# Altium schematic sheet Connector.SchDoc(Connector)
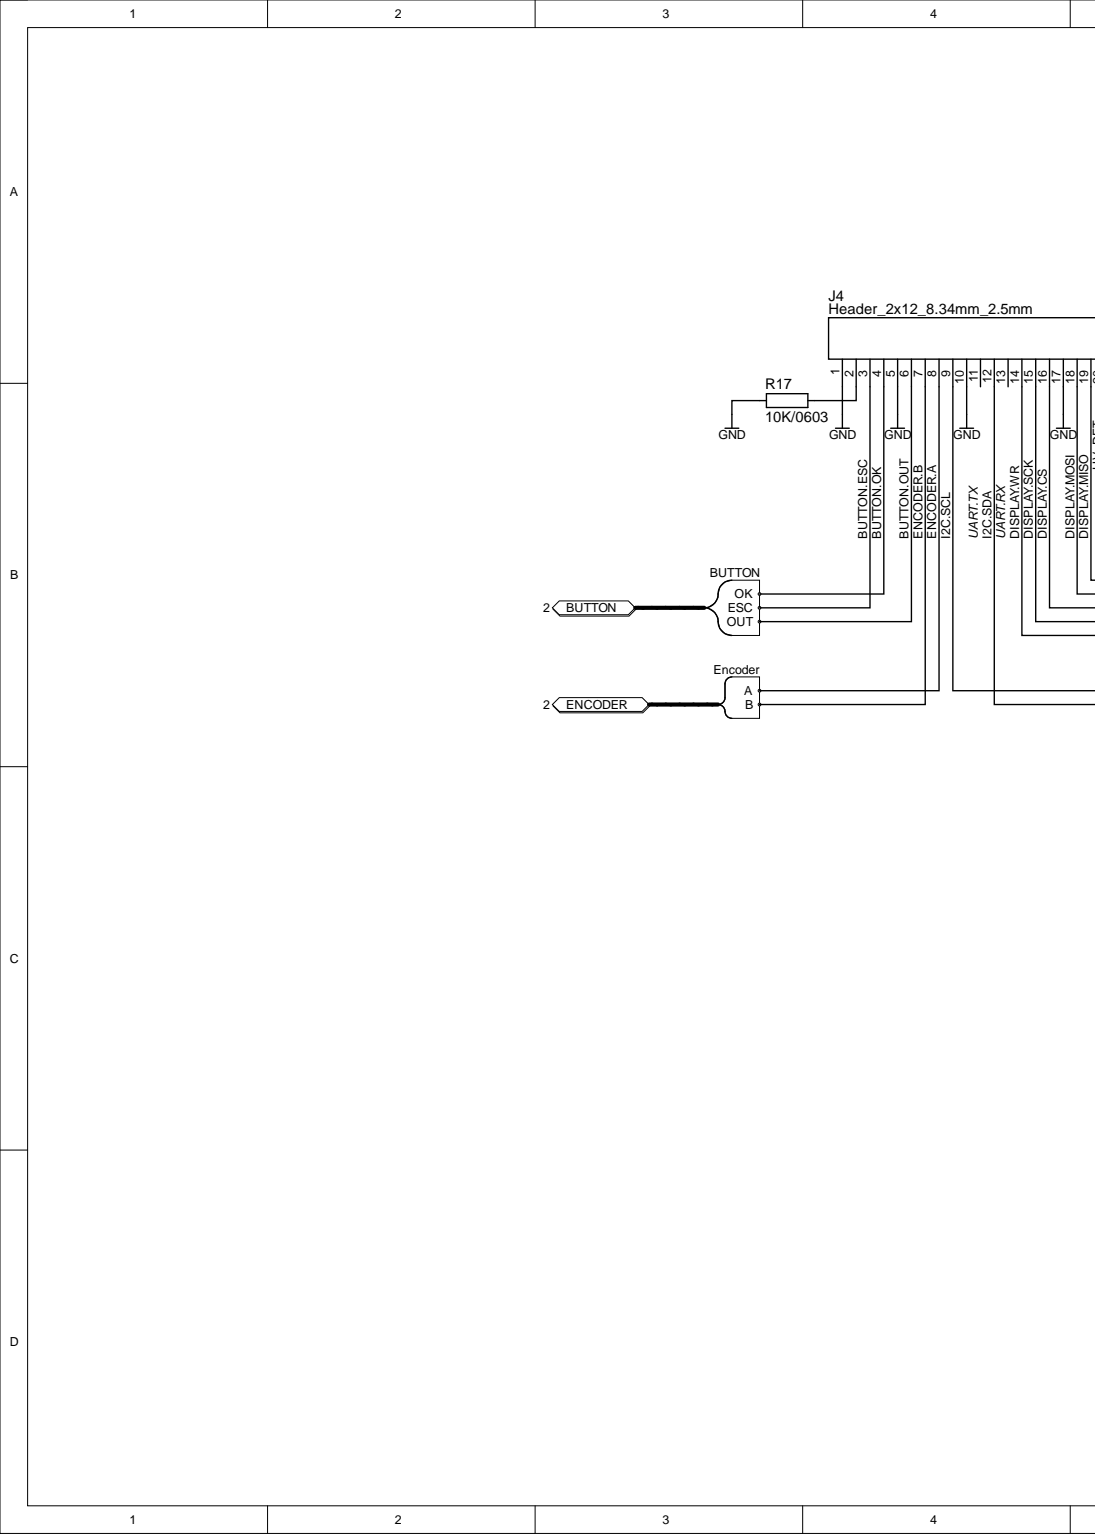
[diagram p0: Connector.SchDoc, left]
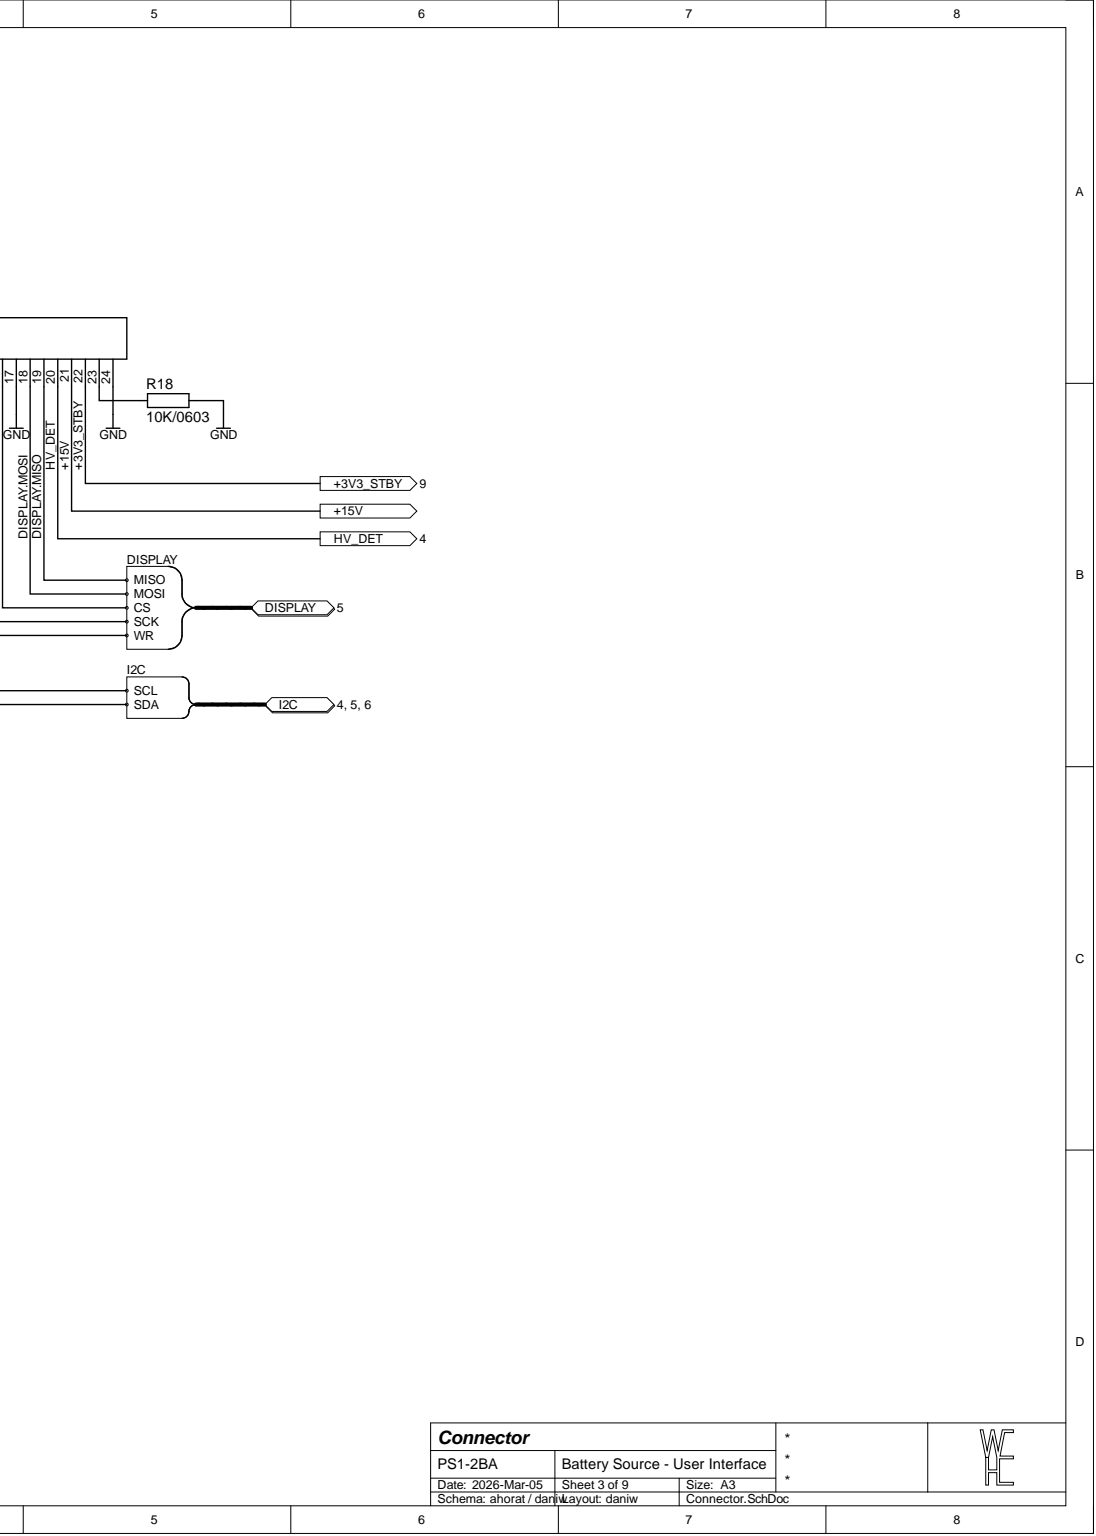
[diagram p1: Connector.SchDoc, right]

## Parts
J4  Header_2x12_8.34mm_2.5mm  [HEAD_254_2X12_834_250]
R17  10K/0603  [R0603-0.40-WW]
R18  10K/0603  [R0603-0.40-WW]

## Ports
+3V3_STBY, +15V, BUTTON, BUTTON.ESC, BUTTON.OK, BUTTON.OUT, DISPLAY, DISPLAY.CS, DISPLAY.MISO, DISPLAY.MOSI, DISPLAY.SCK, DISPLAY.WR, ENCODER, ENCODER.A, ENCODER.B, HV_DET, I2C, I2C.SCL, I2C.SDA

## Nets
GND: J4.1 J4.5 J4.10 J4.17 J4.24 R17.1 R18.2
+3V3_STBY: J4.22 ; port +3V3_STBY
+15V: J4.21 ; port +15V
BUTTON.ESC: J4.3 ; port BUTTON
BUTTON.OK: J4.4 ; port BUTTON
BUTTON.OUT: J4.6 ; port BUTTON
DISPLAY.CS: J4.16 ; port DISPLAY
DISPLAY.MISO: J4.19 ; port DISPLAY
DISPLAY.MOSI: J4.18 ; port DISPLAY
DISPLAY.SCK: J4.15 ; port DISPLAY
DISPLAY.WR: J4.14 ; port DISPLAY
ENCODER.A: J4.8 ; port ENCODER
ENCODER.B: J4.7 ; port ENCODER
HV_DET: J4.20 ; port HV_DET
I2C.SCL: J4.9 ; port I2C
I2C.SDA: J4.12 ; port I2C
NetJ4_2: J4.2 R17.2
NetJ4_11: J4.11
NetJ4_13: J4.13
NetJ4_23: J4.23 R18.1

## Library data of the parts
J4 = Header_2x12_8.34mm_2.5mm: Header 100 mil 2x12, Height 8.34mm, flange height 2.5mm; Manufacturer: GENERIC; Manufacturer 1: Sullins Connector Solutions; Manufacturer Part Number 1: PRPC012DAAN-RC; Database Table Name: CON$; System: Connector; Component Kind: Standard; Part Number: Header_2x12_8.34mm_2.5mm; Supplier 1: Digi-Key; Supplier Part Number 1: S2011EC-12-ND; Library Name: daniw_altium_lib.DbLib
R17 R18 = 10K/0603: RES SM 0603 10K; Manufacturer: GENERIC; Manufacturer 1: YAGEO; Manufacturer Part Number 1: RC0603FR-1310KL; Absolute Value: 10000; Database Table Name: R$; Eurocircuits GPN: GPR060310K; Component Kind: Standard; HelpURL: https://www.yageogroup.com/content/datasheet/asset/file/PYU-RC_GROUP_51_ROHS_L; JLC Type: Basic; Manufacturer 2: YAGEO; Manufacturer 3: UNI-ROYAL(Uniroyal Elec); Manufacturer Part Number 2: RC0603FR-1010KL; Manufacturer Part Number 3: 0603WAF1002T5E; Package: 0603; Part Number: 10K/0603; Supplier 1: Digi-Key; Supplier 2: Digi-Key; Supplier 3: LCSC; Supplier Part Number 1: 13-RC0603FR-1310KLCT-ND; Supplier Part Number 2: 13-RC0603FR-1010KLCT-ND; Supplier Part Number 3: C25804; Value: 10K; Library Name: daniw_altium_lib.DbLib
